# Altium schematic sheet 06-CM4_USB_HDMI_SDCard.SchDoc(06-CM4_USB_HDMI_SDCard)
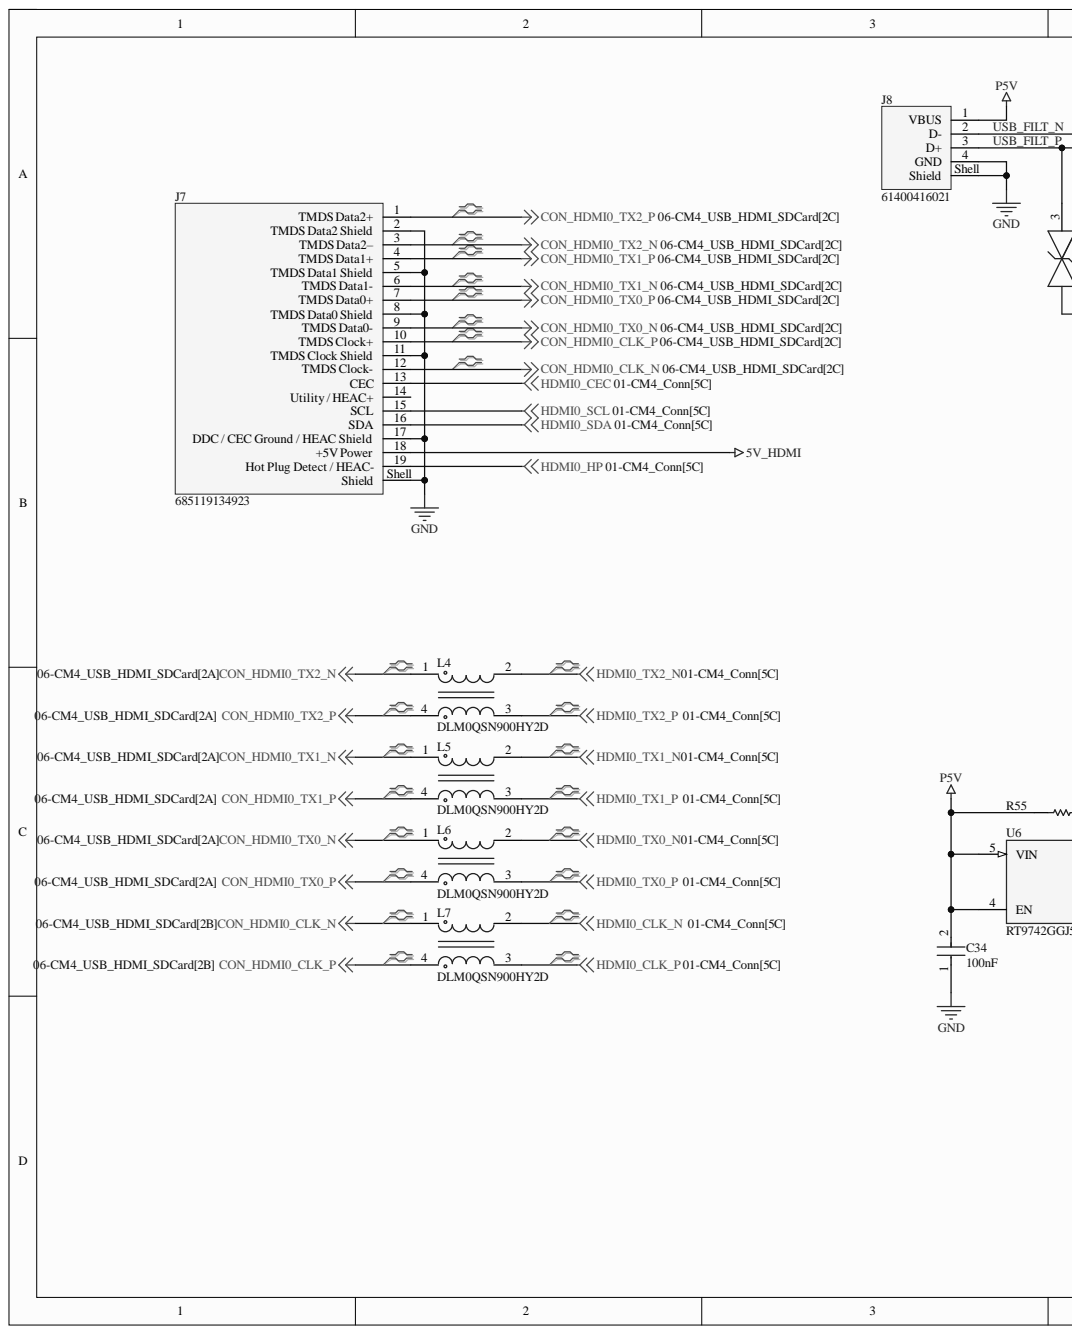
[diagram p0: 06-CM4_USB_HDMI_SDCard.SchDoc, left]
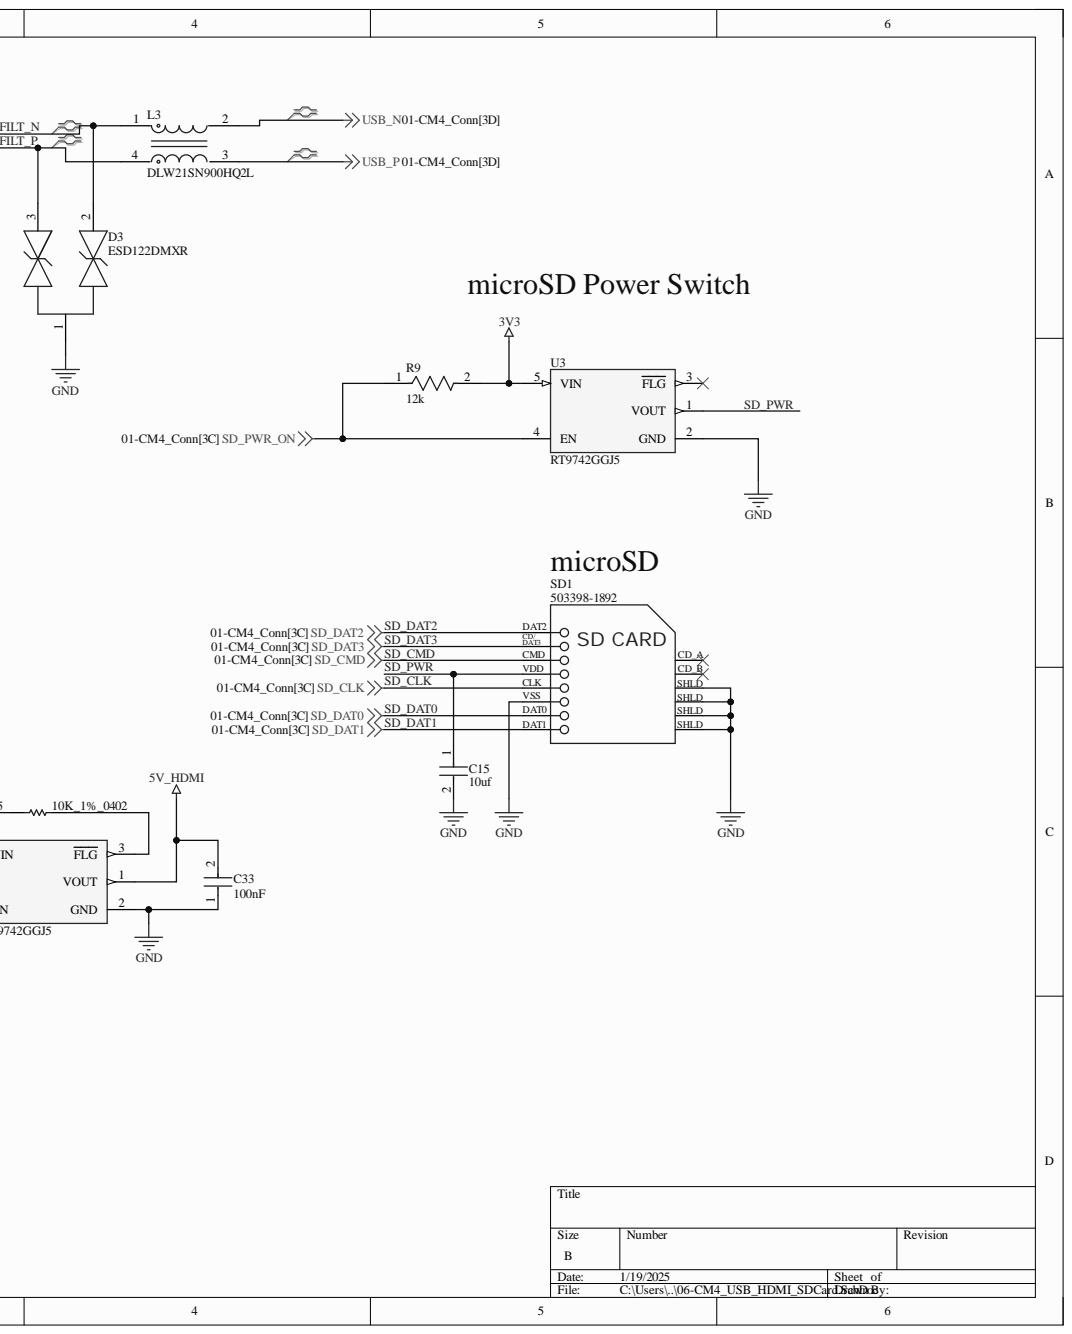
[diagram p1: 06-CM4_USB_HDMI_SDCard.SchDoc, right]

## Parts
C15  10uf  [FP-GRM188-0_2-IPC_C]
C33  100nF  [FP-GRM15-MFG]
C34  100nF  [FP-GRM15-MFG]
D3  ESD122DMXR  [FP-DMX0003A-MFG]
J7  685119134923  [685119134923]
J8  61400416021  [61400416021]
L3  DLW21SN900HQ2L  [FP-DLW21S-MFG]
L4  DLM0QSN900HY2D  [FP-DLM0Q-MFG]
L5  DLM0QSN900HY2D  [FP-DLM0Q-MFG]
L6  DLM0QSN900HY2D  [FP-DLM0Q-MFG]
L7  DLM0QSN900HY2D  [FP-DLM0Q-MFG]
R9  12k  [FP-RC0402-0_4-IPC_C]
R55  10K_1%_0402  [RESC1005X40X25NL10T10]
SD1  503398-1892  [MOLX-503398-1892_V]
U3  RT9742GGJ5  [FP-RT9742GGJ5-IPC_C]
U6  RT9742GGJ5  [FP-RT9742GGJ5-IPC_C]

## Nets
3V3: R9.2 U3.5(VIN)
5V_HDMI: C33.2 J7.18(+5V_Power) U6.1(VOUT)
CON_HDMI0_CLK_N: J7.12(TMDS_Clock-) L7.1
CON_HDMI0_CLK_P: J7.10(TMDS_Clock+) L7.4
CON_HDMI0_TX0_N: J7.9(TMDS_Data0-) L6.1
CON_HDMI0_TX0_P: J7.7(TMDS_Data0+) L6.4
CON_HDMI0_TX1_N: J7.6(TMDS_Data1-) L5.1
CON_HDMI0_TX1_P: J7.4(TMDS_Data1+) L5.4
CON_HDMI0_TX2_N: J7.3(TMDS_Data2–) L4.1
CON_HDMI0_TX2_P: J7.1(TMDS_Data2+) L4.4
GND: C15.2 C33.1 C34.1 D3.1 J7.2(TMDS_Data2_Shield) J7.5(TMDS_Data1_Shield) J7.8(TMDS_Data0_Shield) J7.11(TMDS_Clock_Shield) J7.17(DDC_/_CEC_Ground_/_HEAC_Shield) J7.Shell(Shield) J8.4(GND) J8.Shell(Shield) SD1.6 SD1.11 SD1.12 SD1.13 SD1.14 U3.2(GND) U6.2(GND)
HDMI0_CEC: J7.13(CEC)
HDMI0_CLK_N: L7.2
HDMI0_CLK_P: L7.3
HDMI0_HP: J7.19(Hot_Plug_Detect_/_HEAC-)
HDMI0_SCL: J7.15(SCL)
HDMI0_SDA: J7.16(SDA)
HDMI0_TX0_N: L6.2
HDMI0_TX0_P: L6.3
HDMI0_TX1_N: L5.2
HDMI0_TX1_P: L5.3
HDMI0_TX2_N: L4.2
HDMI0_TX2_P: L4.3
P5V: C34.2 J8.1(VBUS) R55.1 U6.4(EN) U6.5(VIN)
SD_PWR_ON: R9.1 U3.4(EN)
USB_N: L3.2
USB_P: L3.3
SD_CLK: SD1.5
SD_CMD: SD1.3
SD_DAT0: SD1.7
SD_DAT1: SD1.8
SD_DAT2: SD1.1(SD_CARD)
SD_DAT3: SD1.2
SD_PWR: C15.1 SD1.4 U3.1(VOUT)
USB_FILT_N: D3.2 J8.2(D-) L3.1
USB_FILT_P: D3.3 J8.3(D+) L3.4
NetR55_2: R55.2 U6.3(FLG)

Not connected: J7 14(Utility_/_HEAC+); SD1 9 10; U3 3(FLG)

## Library data of the parts
C15 = CMP-06035-045466-1: Chip Multilayer Ceramic Capacitors for General Purpose, 0603, 10uF, X5R, 15%, 20%, 35V; Capacitance: 10uF; Case Code (Imperial): 0603; Case Code (Metric): 1608; Case/Package: 0603; Component Kind: Standard; Dielectric: X5R; Length: 0.063inch; Library Name: Altium Content Vault; Max Operating Temperature: 85°C; Min Operating Temperature: -55°C; Mount: Surface Mount; Packaging: Tape and Reel; Thickness: 0.039inch; Tolerance: 20%; Voltage Rating: 35V; Voltage Rating (DC): 35V; Width: 0.031inch
C33 C34 = CMP-2100-03641-2: CAP CER 0.1UF 16V X7R 0402; Manufacturer: Murata; Manufacturer Part Number: GRM155R71C104KA88D; Capacitance: 0.10μF; Material: Ceramic; Standoff Height: 0mm; Tolerance: 10%; Value: 0.10μF; Voltage Rating: 16Vdc; Category: Cap; Component Kind: Standard; ComponentLink2Description: Datasheet; ComponentLink2URL: https://search.murata.co.jp/Ceramy/image/img/A01X/G101/ENG/GRM155R71H471KA01-01A.pdf; Device Class L1: Passive Components; Device Class L2: Capacitors; Device Class L3: Ceramic Capacitors; Digikey Description: CAP CER 0.1UF 16V X7R 0402; Height: 0.55mm; Ipc Land Pattern Name: CAPC10050X219; Lead Free: yes; Library Name: Altium Content Vault; Mouser Description: 0402 0.1 uF 16V ±10 % Tolerance X7R SMT Multilayer Ceramic Capacitor; Octopart: https://octopart.com/grm155r71c104ka88d-murata-196880; Package: GRM15; RoHS: TRUE; Temperature: -55°C to +125°C; Temperature Characteristic: X7R; Temperature Range High: +125°C; Temperature Range Low: -55°C
D3 = CMP-04989-000013-1: TVS DIODE 3.6V 8.4V 3X2SON; Average Rectified Current: 10A; Element Configuration: Common Cathode; Interface: USB; Max Reverse Voltage (DC): 100V; Min Breakdown Voltage: 5.1V; Number of Bidirectional Channels: 2; Peak Pulse Current: 2.5A; Peak Pulse Power: 20W; Power Line Protection: No; Case/Package: TO-220-3; Clamping Voltage: 8.4V; Component Kind: Standard; Library Name: Altium Content Vault; Max Operating Temperature: 125°C; Min Operating Temperature: -40°C; Mount: Through Hole; Number of Channels: 2; Number of Pins: 3; Packaging: Rail/Tube; Resistor Type: Zener; Reverse Standoff Voltage: 3.6V; RoHS Compliant: Yes
J7 = CMP-1502-00169-3: NexarPartId: 18818285; Body Material: Plastic; Connector Type: HDMI; Contact Resistance: 30mΩ; Current Rating: 500mA; Gender: Receptacle; Insulation Resistance: 10MΩ; Number of Contacts: 19; Voltage Rating: 40V; Color: Black; Component Kind: Standard; Contact Material: Bronze, Gold; Features: Shielded; Height: 5.55mm; Length: 12.15mm; Library Name: Altium Content Vault; Max Operating Temperature: 85°C; Min Operating Temperature: -25°C; Mount: Horizontal, Surface Mount; Number of Pins: 19; Number of Positions: 19; Orientation: Horizontal; Packaging: Tape and Reel; REACH SVHC: Unknown; RoHS: Compliant; Termination: SMD/SMT; Width: 15mm
J8 = CMP-1502-03227-2: NexarPartId: 21041530; Connector Type: USB; Contact Resistance: 20mΩ; Current Rating: 1.5A; Gender: Receptacle; Insulation Resistance: 1GΩ; Number of Contacts: 4; Number of Ports: 1; Operating Supply Voltage: 30V; USB Standard: USB 2.0; Color: White; Component Kind: Standard; Contact Material: Copper; Contact Plating: Gold; Flammability Rating: UL94 V-0; Insulation Material: PBT; Lead Free: Lead Free; Length: 15.8mm; Library Name: Altium Content Vault; Max Operating Temperature: 105°C; Min Operating Temperature: -40°C; Mount: Horizontal, Through Hole; Number of Pins: 4; Number of Positions: 4; Orientation: Horizontal; REACH SVHC: Unknown; RoHS: Compliant; Termination: Solder; Voltage Rating: 30V; Voltage Rating (DC): 30V; Width: 14.4mm
L3 = CMP-2000-06163-2: Common Mode Choke, 0805, 90Ω @ 100MHz, 0.41Ω, 25%, 280mA; DC Resistance (DCR): 410mR; Impedance: 90R; Number of Lines: 2; Shielding: Unshielded; Case Code (Imperial): 0805; Case/Package: 0805; Component Kind: Standard; Current: 280mA; Current Rating: 280mA; DC Current: 280mA; Depth: 1.4mm; Height: 0.055inch; Lead Free: Lead Free; Length: 2mm; Library Name: Altium Content Vault; Max DC Current: 280mA; Max Operating Temperature: 85°C; Military Standard: Not; Min Operating Temperature: -40°C; Mount: Surface Mount; Package Quantity: 1; Packaging: Tape and Reel; Radiation Hardening: No; REACH SVHC: No SVHC; Resistor Type: Wirewound; RoHS Compliant: Yes; Series: EMIFIL®, DLW21S; Termination: SMD/SMT; Test Frequency: 100MHz; Tolerance: 25%; Weight: 0.000388oz; Width: 0.047inch
L4-L7 = CMP-06045-000424-1: Chip Common Mode Choke Coil Monolithic 025020 50mA 90Ohm @ 100MHz; Impedance: 90R; Component Kind: Standard; Length: 650um; Library Name: Altium Content Vault; Width: 500um
R9 = CMP-2002-07378-2: RES SMD 12K OHM 1% 1/16W 0402; Max Power Dissipation: 63mW; Power Rating: 62.5mW; Resistance: 12kR; Temperature Coefficient: 100ppm/°C; Voltage Rating: 50V; Case Code (Imperial): 0402; Case Code (Metric): 1005; Case/Package: 0402; Component Kind: Standard; Construction: Rectangular; Depth: 0.5mm; Features: Moisture Resistant; Height: 0.016inch; Lead Free: Lead Free; Length: 1mm; Library Name: Altium Content Vault; Max Operating Temperature: 155°C; Military Standard: Not; Min Operating Temperature: -55°C; Number of Terminations: 2; Package Quantity: 1; Packaging: Tape and Reel; REACH SVHC: No SVHC; Resistance Tolerance: 1%; Resistor Type: Thick Film; RoHS Compliant: Yes; Series: RC; Size Code: 0402; Termination: SMD/SMT; Tolerance: 1%; Weight: 2.3E-05oz; Width: 0.02inch
R55 = CMP-2002-04694-1: Manufacturer: Bourns; Part Number: CR0402-FX-1002GLF; Component Kind: Standard; Library Name: Altium Content Vault
SD1 = CMP-2000-05621-1: Micro SD Connector, Pitch 1.1 mm, 8 Position, Height 1.4 mm, -25 to 85 degC, RoHS, Tape and Reel; Brand: Molex; Current Rating: 500mA; Voltage Rating: 10VAC/DC; Component Kind: Standard; Datasheet URL: http://www.molex.com/pdm_docs/sd/5033981892_sd.pdf; Datasheet Version: Rev. E, 04/2006; Factory Pack Quantity: 2400; Library Name: Altium Content Vault; Manufacturer: Molex; Manufacturer URL: http://www.molex.com/; Mounting Technology: SurfaceMount; Package Description: Micro SD Connector, Pitch 1.1 mm, 8 Position, Height 1.4 mm; Package Reference: 503398-1892; Packaging: Reel; Product Category: MemoryCardConnectors; RoHS: Details; Series: 503398
U3 U6 = CMP-79883-000001-1: IC PWR SWTCH N-CHAN 1:1 TSOT23-5; Fault Protection: Over Temperature; Interface: On/Off; Max Output Current: 1A; Number of Outputs: 1; On-State Resistance: 70mR; Output Configuration: High Side; Case/Package: SOT-23-5; Component Kind: Standard; Library Name: Altium Content Vault; Max Operating Temperature: 85°C; Min Operating Temperature: -40°C; Number of Pins: 23; Packaging: Tape and Reel; REACH SVHC: No SVHC; RoHS Compliant: Yes
